# Altium schematic sheet 09_RTC.SchDoc
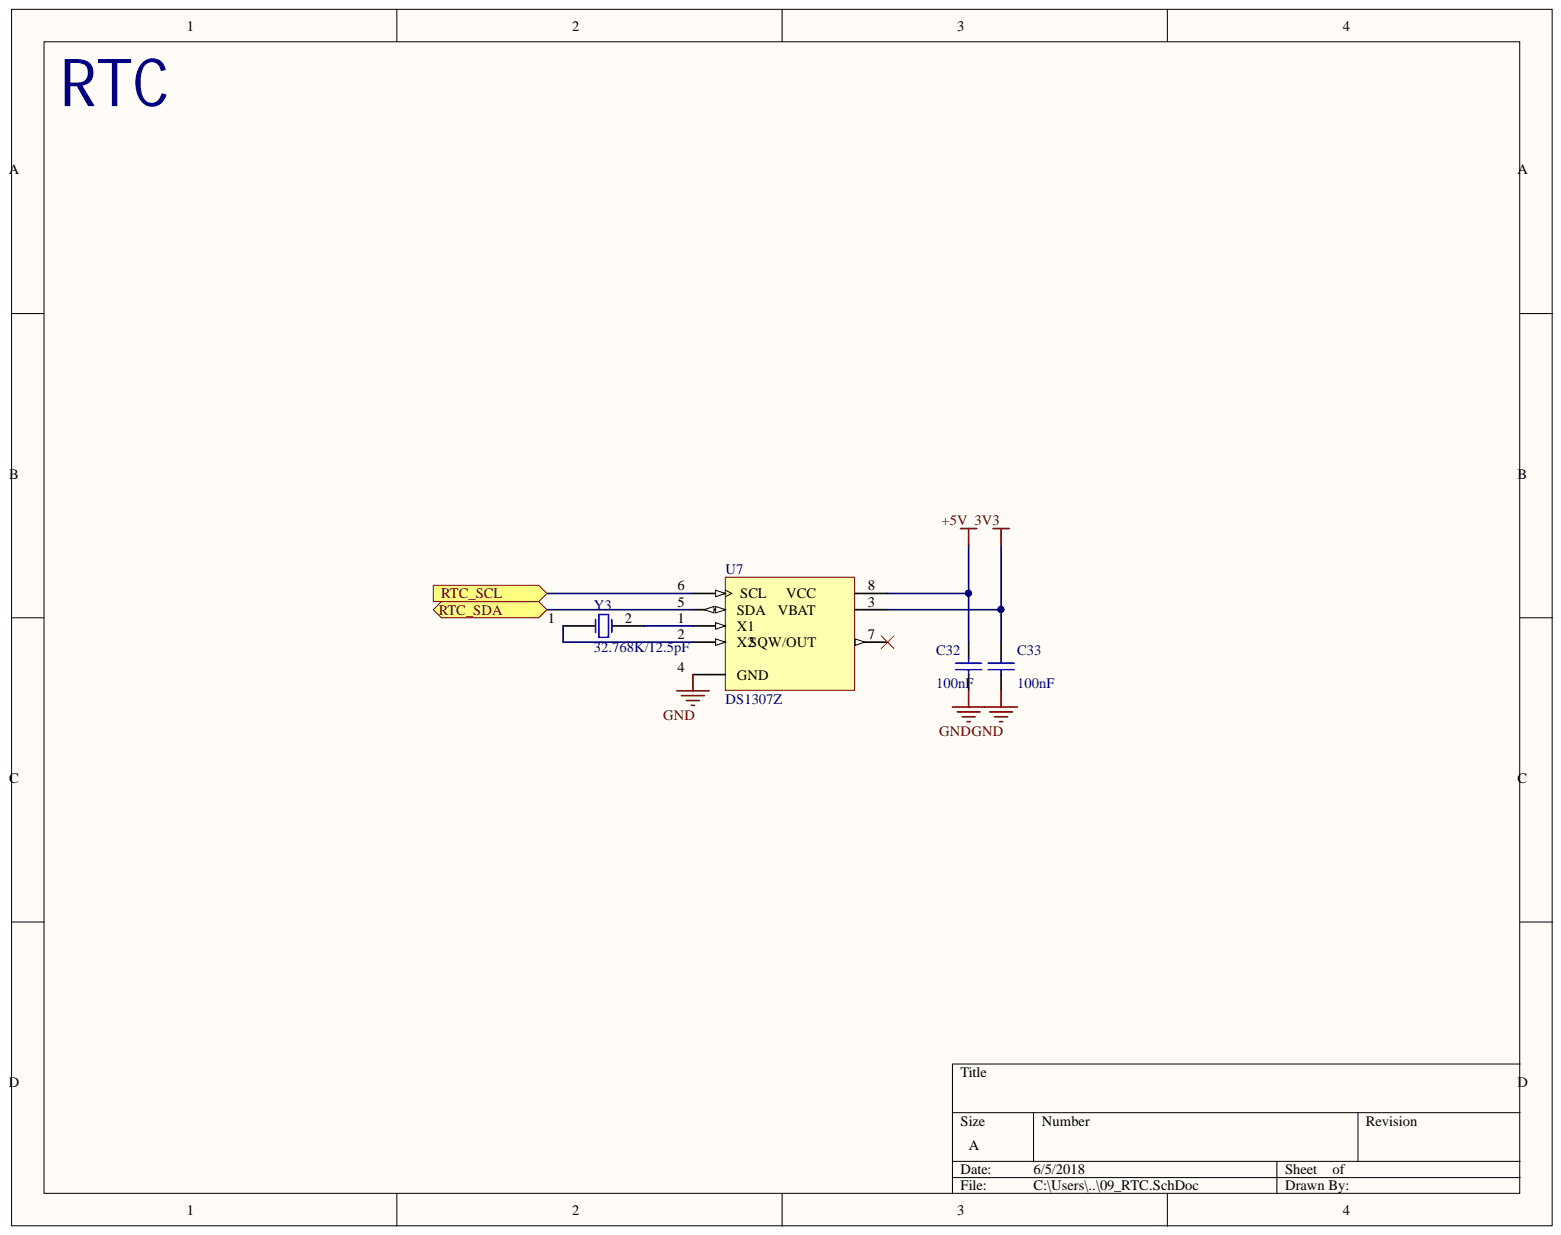
[diagram p0: 09_RTC.SchDoc]

## Parts
C32  100nF  [CAP_0603_SMD]
C33  100nF  [CAP_0603_SMD]
U7  DS1307Z  [SOIC-8(3d)]
Y3  32.768K/12.5pF  [FC-135]

## Ports
RTC_SCL, RTC_SDA

## Nets
3V3: C33.2 U7.3
+5V: C32.2 U7.8
GND: C32.1 C33.1 U7.4(GND)
RTC_SCL: U7.6(SCL) ; port RTC_SCL
RTC_SDA: U7.5(SDA) ; port RTC_SDA
NetU7_1: U7.1(X1) Y3.2
NetU7_2: U7.2(X2) Y3.1

Not connected: U7 7

## Library data of the parts
C32 C33 = Cap: Capacitor; Manufacturer Part Number: GCM188R71C104KA37D; Component Kind: Standard; LatestRevisionDate: 17-Jul-2002; LatestRevisionNote: Re-released for DXP Platform.; Library Name: Miscellaneous Devices.IntLib; PackageDescription: Radial Cap, Thru-Hole; 2 Leads; 0.3 in Pin Spacing; PackageReference: SMD 0603; Published: 8-Jun-2000; Signal Integrity: Cap; Simulation: CAP; Value: 100nF; Publisher: Altium Limited
U7 = DS1307Z: 64 X 8 Serial Real-Time Clock; Code_IPC: SOIC127P600-8; Component Kind: Standard; ComponentLink1Description: Manufacturer Link; ComponentLink1URL: http://www.maxim-ic.com/; ComponentLink2Description: Datasheet; ComponentLink2URL: http://pdfserv.maxim-ic.com/en/ds/DS1307.pdf; ComponentLink3Description: Discontinuation Notice; ComponentLink3URL: http://www.maxim-ic.com/qa/pdfs/non-obsolescence-letter.pdf; DatasheetVersion: 10-Aug-1999; LatestRevisionDate: 13-Apr-2006; LatestRevisionNote: IPC-7351 Footprint Added.; Library Name: Dallas Peripheral Real Time Clock.IntLib; PackageDescription: 8-Pin Small Outline Integrated Circuit 1.27 mm Pitch; PackageReference: SOIC8N; PackageVersion: 1999; Published: 13-Oct-2000; Publisher: Altium Limited
Y3 = XTAL: Crystal Oscillator; Component Kind: Standard; LatestRevisionDate: 17-Jul-2002; LatestRevisionNote: Re-released for DXP Platform.; Library Name: Miscellaneous Devices.IntLib; PackageDescription: Crystal, Thru-Hole; 2 Leads; Body 3.1 x 8.2 mm (Dia.xH); PackageReference: R38; Published: 8-Jun-2000; Simulation: XTAL; value: 32.768K/12.5pF; Publisher: Altium Limited
